# Altium schematic sheet Single_Channel_fNIRS.SchDoc(Single_Channel_fNIRS)
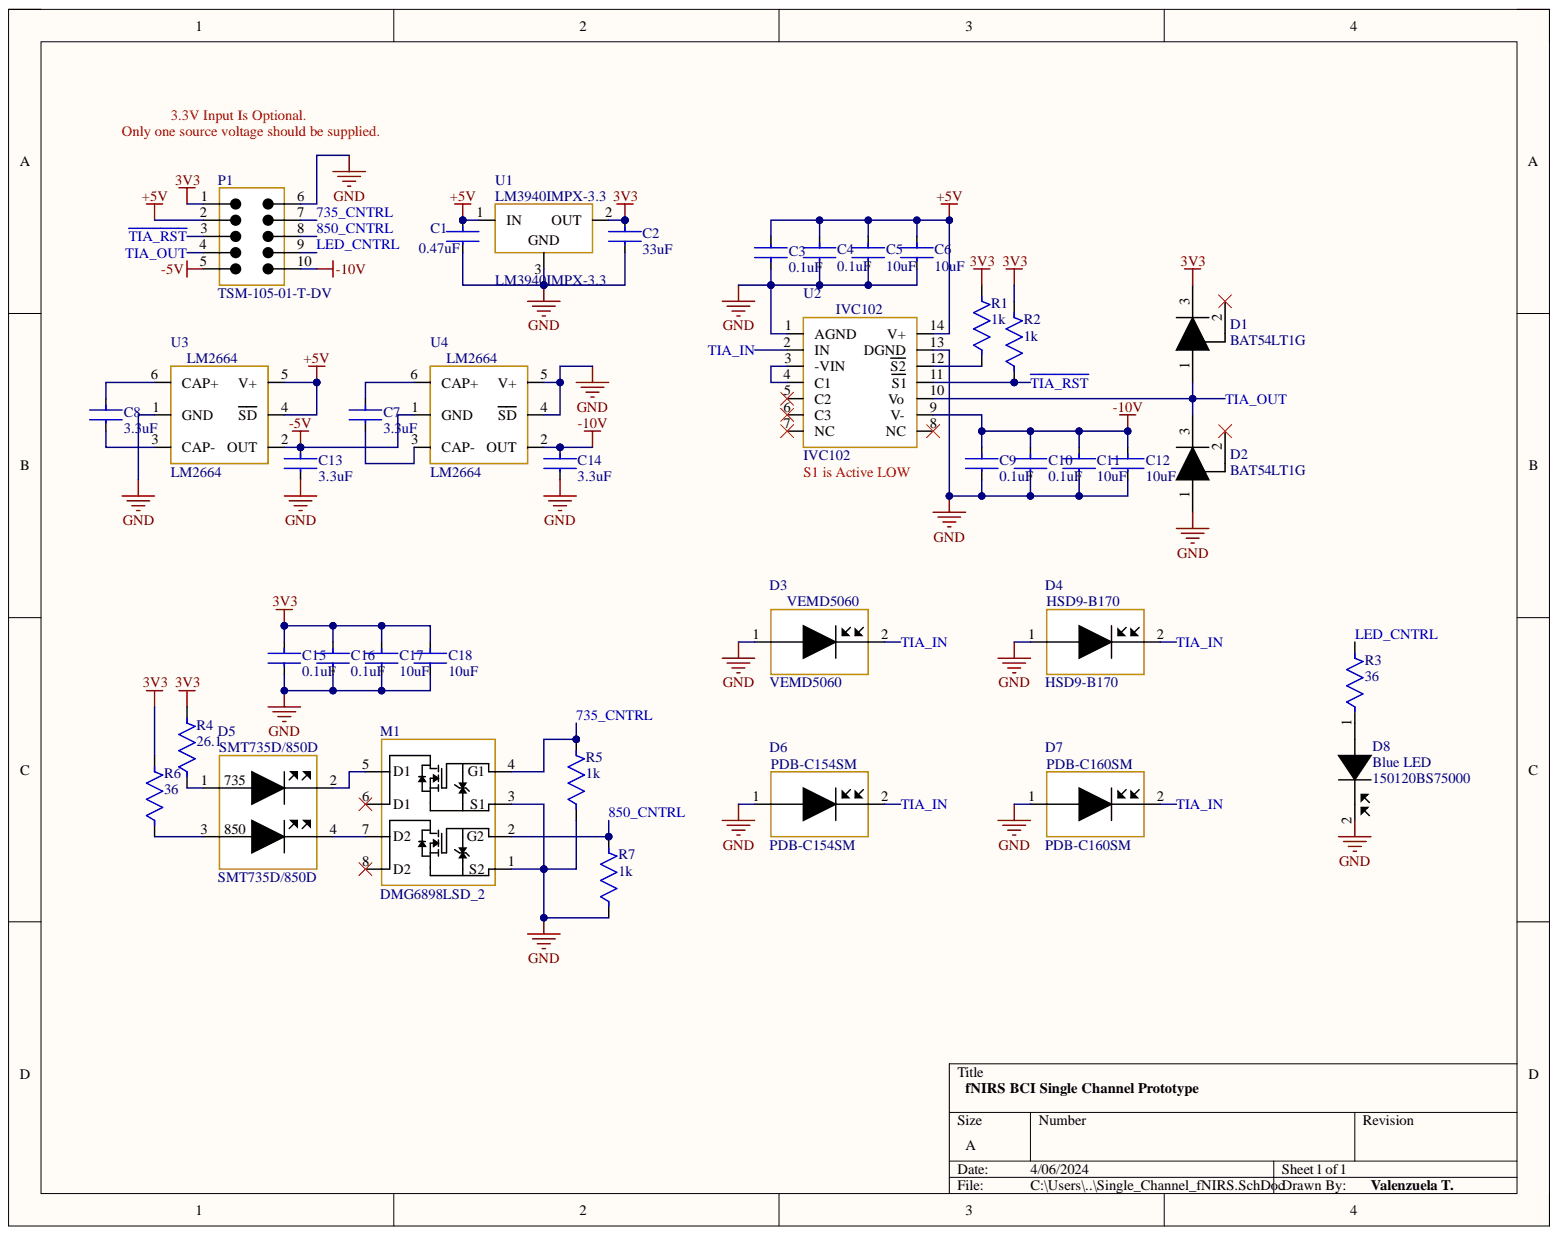
[diagram p0: Single_Channel_fNIRS.SchDoc]

## Parts
C1  0.47uF  [CAPC3216X180N]
C2  33uF  [CAPC3216X180N]
C3  0.1uF  [CAPC3216X180N]
C4  0.1uF  [CAPC3216X180N]
C5  10uF  [CAPC3216X180N]
C6  10uF  [CAPC3216X180N]
C7  3.3uF  [CAPC1210(3225)190_M]
C8  3.3uF  [CAPC1210(3225)190_M]
C9  0.1uF  [CAPC3216X180N]
C10  0.1uF  [CAPC3216X180N]
C11  10uF  [CAPC3216X180N]
C12  10uF  [CAPC3216X180N]
C13  3.3uF  [CAPC1210(3225)190_M]
C14  3.3uF  [CAPC1210(3225)190_M]
C15  0.1uF  [CAPC3216X180N]
C16  0.1uF  [CAPC3216X180N]
C17  10uF  [CAPC3216X180N]
C18  10uF  [CAPC3216X180N]
D1  BAT54LT1G  [BAT54LT1]
D2  BAT54LT1G  [BAT54LT1]
D3  VEMD5060  [VEMD5060]
D4  HSD9-B170  [HSD9-B170]
D5  SMT735D/850D  [SMT735D_850D]
D6  PDB-C154SM  [PDB-C154SM]
D7  PDB-C160SM  [DS-PDB-C160SM]
D8  Blue LED  Color: Blue  [150120BS75000]
M1  DMG6898LSD_2  [SO-8-DMG6898LSD]
P1  TSM-105-01-T-DV  [SAMTEC_TSM-105-01-T-DV-TR]
R1  1k  [R1206]
R2  1k  [R1206]
R3  36  [R1206]
R4  26.1  [R1206]
R5  1k  [R1206]
R6  36  [R1206]
R7  1k  [R1206]
U1  LM3940IMPX-3.3  [LM3940]
U2  IVC102  [D0014A_M]
U3  LM2664  [LM2664]
U4  LM2664  [LM2664]

## Nets
3V3: C2.1 C15.1 C16.1 C17.1 C18.1 D1.3 P1.1 R1.2 R2.2 R4.2 R6.2 U1.2
+5V: C1.1 C3.2 C4.1 C5.1 C6.1 P1.2 U1.1 U2.14(V+) U3.4(SD) U3.5(V+)
-5V: C13.1 P1.5 U3.2(OUT) U4.1(GND)
-10V: C9.1 C10.1 C11.1 C12.1 C14.1 P1.10 U2.9(V-) U4.2(OUT)
GND: C1.2 C2.2 C3.1 C4.2 C5.2 C6.2 C9.2 C10.2 C11.2 C12.2 C13.2 C14.2 C15.2 C16.2 C17.2 C18.2 D2.1 D3.1 D4.1 D6.1 D7.1 D8.2 M1.1(S2) M1.3(S1) P1.6 R5.1 R7.1 U1.3 U2.1(AGND) U2.13(DGND) U3.1(GND) U4.4(SD) U4.5(V+)
735_CNTRL: M1.4(G1) P1.7 R5.2
850_CNTRL: M1.2(G2) P1.8 R7.2
~{TIA_RST}: P1.3 R2.1 U2.11(S1)
LED_CNTRL: P1.9 R3.2
TIA_IN: D3.2 D4.2 D6.2 D7.2 U2.2(IN)
TIA_OUT: D1.1 D2.3 P1.4 U2.10(Vo)
NetC7_1: C7.1 U4.6(CAP+)
NetC7_2: C7.2 U4.3(CAP-)
NetC8_1: C8.1 U3.6(CAP+)
NetC8_2: C8.2 U3.3(CAP-)
NetD5_1: D5.1 R4.1
NetD5_2: D5.2 M1.5(D1)
NetD5_3: D5.3 R6.1
NetD5_4: D5.4 M1.7(D2)
NetD8_1: D8.1 R3.1
NetR1_1: R1.1 U2.12(S2)
NetU2_3: U2.3(-VIN) U2.4(C1)

Not connected: D1 2; D2 2; M1 6(D1) 8(D2); U2 5(C2) 6(C3) 7(NC) 8(NC)

## Library data of the parts
C1 = C3216X7R2A474K160AA: 1206; Component Kind: Standard; Library Name: Single_Channel_fNIRS.SchLib
C2 = C3216X5R1E336M160AC: 1206; Component Kind: Standard; Library Name: Single_Channel_fNIRS.SchLib
C3 C4 C9 C10 C15 C16 = C1206C104K5RAC7210: 1206; Manufacturer: KEMET; Automotive Grade: Grade 0; Capacitance: 0.10 μF; Material: Ceramic; Temperature Characteristic: X7R; Temperature Coefficient: 15%; Tolerance: 0.1; Value: 0.10 μF; Voltage Rating: 50 V; Automotive: Yes; Category: Cap; Component Kind: Standard; ComponentLink2Description: Datasheet; ComponentLink2URL: https://content.kemet.com/datasheets/KEM_C1007_X8R_ULTRA_150C_SMD.pdf; Device Class L1: Passive Components; Device Class L2: Capacitors; Device Class L3: Ceramic Capacitors; Digikey Description: CAP CER 0.1UF 50V X7R 1206; Height: 0.88mm; Ipc Land Pattern Name: CAPC320160X78; Lead Free: Yes; Manufacturer Part Number: C1206C104K5RAC7210; Mouser Description: Multilayer Ceramic Capacitors MLCC - SMD/SMT 50volts 0.1uF X7R 10%; Octopart: https://octopart.com/c1206c104k5rac7210-kemet-3919856; Package: C1206C-EB; RoHS: TRUE; Temperature: -55°C to +150°C; Temperature Range High: +150°C; Temperature Range Low: -55°C; Library Name: Single_Channel_fNIRS.SchLib
C5 C6 C11 C12 C17 C18 = CL31B106KOHNNWE: 1206; Component Kind: Standard; Library Name: Single_Channel_fNIRS.SchLib
C7 C8 C13 C14 = C1210C335K5RAC7800: 1210; Component Kind: Standard; Library Name: Single_Channel_fNIRS.SchLib
D1 D2 = BAT54L: Schottky Barrier Diode, 3-Pin SOT-23, Pb-Free, Tape and Reel; Manufacturer: On Semiconductor; Configuration: Single; IFSM Max (A): 0.6; trr Max (ns): 5; Component Kind: Standard; ComponentLink1Description: Manufacturer URL; ComponentLink1URL: http://www.onsemi.com/; ComponentLink2Description: Datasheet; ComponentLink2URL: http://www.onsemi.cn/pub_link/Collateral/BAT54LT1-D.PDF; ComponentLink3Description: Package Specification; ComponentLink3URL: http://www.onsemi.cn/pub_link/Collateral/318-08.PDF; DatasheetVersion: Rev. 12; Mounting Technology: Surface Mount; PackageDescription: 3-Pin Small Outline Transistor, Body 2.92 x 1.3 mm, Pitch 0.95 mm; PackageReference: SOT-23-3-318-08; PackageVersion: Rev. AP, 11/2009; Packing: Tape and Reel; PartNumber: BAT54LT1G; RoHS: TRUE; Library Name: Single_Channel_fNIRS.SchLib
D8 = 150120BS75000: Single Color LED, Blue, Water Clear, 2mm; Manufacturer: Wurth Electronics; Forward Current-Max: 30mA; Luminous Intensity-Nom: 145mcd; Number of Functions: 1; Overall Height: 0.68mm; Shape: Rectangular; Viewing Angle: 140°; Category: LEDs; Component Kind: Standard; ComponentLink1Description: https://datasheet.ciiva.com:443/pdfs/VipMasterIC/IC/MIDC/MIDC-S-A0007455946/MIDC-S-A0007455946-1.pdf?src-supplier=IHS+Markit; ComponentLink1URL: https://datasheet.ciiva.com:443/pdfs/VipMasterIC/IC/MIDC/MIDC-S-A0007455946/MIDC-S-A0007455946-1.pdf?src-supplier=IHS+Markit; ComponentLink2Description: https://datasheet.ciiva.com/22656/150120bs75000-22656976.pdf?src-supplier=Element14; ComponentLink2URL: https://datasheet.ciiva.com/22656/150120bs75000-22656976.pdf?src-supplier=Element14; ComponentLink3Description: https://datasheet.ciiva.com/29279/759440-da-01-en-chipled-1206-w-kl-blau-150120bs75000-29279045.pdf?src-supplier=Conrad; ComponentLink3URL: https://datasheet.ciiva.com/29279/759440-da-01-en-chipled-1206-w-kl-blau-150120bs75000-29279045.pdf?src-supplier=Conrad; ComponentLink4Description: https://datasheet.ciiva.com/29561/150120bs75000-29561882.pdf?src-supplier=Digikey; ComponentLink4URL: https://datasheet.ciiva.com/29561/150120bs75000-29561882.pdf?src-supplier=Digikey; Lens Style: WATER CLEAR; Manufacturer Part Number: 150120BS75000; Max Operating Temperature: 85°C; Min Operating Temperature: -40°C; Mount: Surface Mount; Number of Pins: 2; Optoelectronic Device Type: SINGLE COLOR LED; Packaging: Tape and Reel; Pricing 1: 1=0.262, 10=0.257, 25=0.25, 50=0.242, 100=0.212, 500=0.194 (USD); Reach SVHC Compliant: Yes; RoHS: Yes; RoHS Compliant: Yes; Size: 2mm; Stock 1: 1765; Supplier 1: Newark; Supplier Part Number 1: 150120BS75000; Terminal Pitch: 3mm; Library Name: Single_Channel_fNIRS.SchLib
P1 = TSM-105-01-T-DV: 0.025" SQ Post Header, Surface Mount, Vertical, -55 to 105 degC, 2.54 mm Pitch, 10-Pin, Male, RoHS; Manufacturer: Samtec; Pitch: 2.54 mm; Component Kind: Standard; ComponentLink1Description: Manufacturer URL; ComponentLink1URL: http://www.samtec.com; ComponentLink2Description: Datasheet; ComponentLink2URL: http://www.samtec.com/documents/webfiles/pdf/TSM.PDF; ComponentLink3Description: Package Specification; ComponentLink3URL: http://www.samtec.com/documents/webfiles/cpdf/TSM-1XX-XX-XXX-XX-X-XXX-X-MKT.pdf; DatasheetVersion: F-214; Mounting Technology: Surface Mount; Orientation: Vertical; PackageDescription: Double Row Gullwing ASM; PackageReference: TSM-105-01-X-DV; PackageVersion: DJ, 4/1991; PartNumber: TSM-105-01-T-DV; RoHS: True; Temperature Range: -55 to 105 degC; Library Name: Single_Channel_fNIRS.SchLib
R1 R2 R5 R7 = RT1206BRD071KL: 1206 1kOhm; Component Kind: Standard; Library Name: Single_Channel_fNIRS.SchLib
R3 R6 = RT1206BRD0736RL: 1206 36 Ohm; Manufacturer: Yageo; Additional Feature: HIGH PRECISION; Package Height: 0.55mm; Package Length: 3.1mm; Package Width: 1.6mm; Rated Power Dissipation (P): 250mW; Rated Temperature: 70°C; Resistance: 36R; Temperature Coefficient: 25ppm/°C; Tolerance: 0.1%; Working Voltage: 200V; Category: Chip SMD Resistors; Component Kind: Standard; ComponentLink1Description: https://datasheet.ciiva.com:443/pdfs/VipMasterIC/IC/YAGO/YAGO-S-A0008510989/YAGO-S-A0008510989-1.pdf?src-supplier=IHS+Markit; ComponentLink1URL: https://datasheet.ciiva.com:443/pdfs/VipMasterIC/IC/YAGO/YAGO-S-A0008510989/YAGO-S-A0008510989-1.pdf?src-supplier=IHS+Markit; ComponentLink2Description: https://datasheet.ciiva.com/2587/pyu-rt-1-to-0-05-rohs-l-5-2587658.pdf?src-supplier=Digikey; ComponentLink2URL: https://datasheet.ciiva.com/2587/pyu-rt-1-to-0-05-rohs-l-5-2587658.pdf?src-supplier=Digikey; ComponentLink3Description: https://datasheet.ciiva.com/12811/pyu-rt-1-to-0-05-rohs-l-4-12811975.pdf?src-supplier=Mouser; ComponentLink3URL: https://datasheet.ciiva.com/12811/pyu-rt-1-to-0-05-rohs-l-4-12811975.pdf?src-supplier=Mouser; Construction: Chip; Manufacturer Part Number: RT1206BRD0736RL; Max Operating Temperature: 155°C; Min Operating Temperature: -55°C; Mount: Surface Mount; Number of Pins: 2; Package Style: SMTMeter; Packaging: Tape and Reel; Pricing 1: 1=0.33, 10=0.241, 50=0.1868, 100=0.166, 500=0.1245, 1000=0.10938 (USD); Reach SVHC Compliant: Yes; Resistor Type: FIXED RESISTOR; RoHS: Yes; RoHS Compliant: Yes; Size Code: 1206; Stock 1: 9860; Supplier 1: Digikey; Technology: THIN FILM; Termination: Wraparound; Library Name: Single_Channel_fNIRS.SchLib
R4 = RQ73C2B26R1BTD: 1206 26.1 Ohm; Manufacturer: TE; Automotive Grade: Grade 0; Power Rating: 0.4W; Resistance: 26.1Ω; Temperature Coefficient: 10ppm/°C; Tolerance: 0.1%; Value: 26.1Ω; Voltage Rating: 200V; Automotive: Yes; Category: Res; Component Kind: Standard; ComponentLink2Description: Datasheet; ComponentLink2URL: https://www.te.com/commerce/DocumentDelivery/DDEController?Action=showdoc&DocId=Data+Sheet%7F1773270-2%7FA%7Fpdf%7FEnglish%7FENG_DS_1773270-2_A.pdf%7F9-2176388-167; Composition: Thin Film; Device Class L1: Passive Components; Device Class L2: Resistors; Device Class L3: Chip SMD Resistors; Height: 0.75mm; Ipc Land Pattern Name: RESC310160X60; Lead Free: Yes; Manufacturer Part Number: RQ73C2B26R1BTD; Octopart: https://octopart.com/rq73c2b26r1btd-te+connectivity-93911368; Package: RQ732B; RoHS: TRUE; Series: RQ73; Temperature: -55°C to +155°C; Temperature Range High: +155°C; Temperature Range Low: -55°C; Library Name: Single_Channel_fNIRS.SchLib
U3 U4 = LM2664: Switched Capacitor Voltage Converter, 6-pin SOT-23; Manufacturer: Texas Instruments; CompType: Switch Caps; PackageTopMarking: S03A*XTT; PowerWise: N; Code_IPC: SOT26A-6; Code_JEDEC: MO-178-AB; Component Kind: Standard; ComponentLink1Description: Datasheet URL; ComponentLink1URL: http://www.ti.com/general/docs/lit/getliterature.tsp?genericPartNumber=LM2664&fileType=pdf; PackageDescription: Molded SOT-23, .115 x .063 x .040in Body, 6 Lead, .0375in in Pitch; PackageReference: DBV0006A; PinCount: 6; RoHS: N; Library Name: Single_Channel_fNIRS.SchLib
D3 = VEMD5060: Component Kind: Standard; Library Name: Single_Channel_fNIRS.SchLib
D4 = HSD9-B170: Component Kind: Standard; Library Name: Single_Channel_fNIRS.SchLib
D5 = SMT735D/850D: Component Kind: Standard; Library Name: Single_Channel_fNIRS.SchLib
D6 = PDB-C154SM: Component Kind: Standard; Library Name: Single_Channel_fNIRS.SchLib
D7 = PDB-C160SM: Component Kind: Standard; Library Name: Single_Channel_fNIRS.SchLib
M1 = DMG6898LSD_2: Component Kind: Standard; Library Name: Single_Channel_fNIRS.SchLib
U1 = LM3940IMPX-3.3: Component Kind: Standard; Library Name: Single_Channel_fNIRS.SchLib
U2 = IVC102: Component Kind: Standard; Library Name: Single_Channel_fNIRS.SchLib
